# Altium schematic sheet IoBPMBlockDiagram V1.0.SchDoc("IoBPMBlockDiagram V1.0")
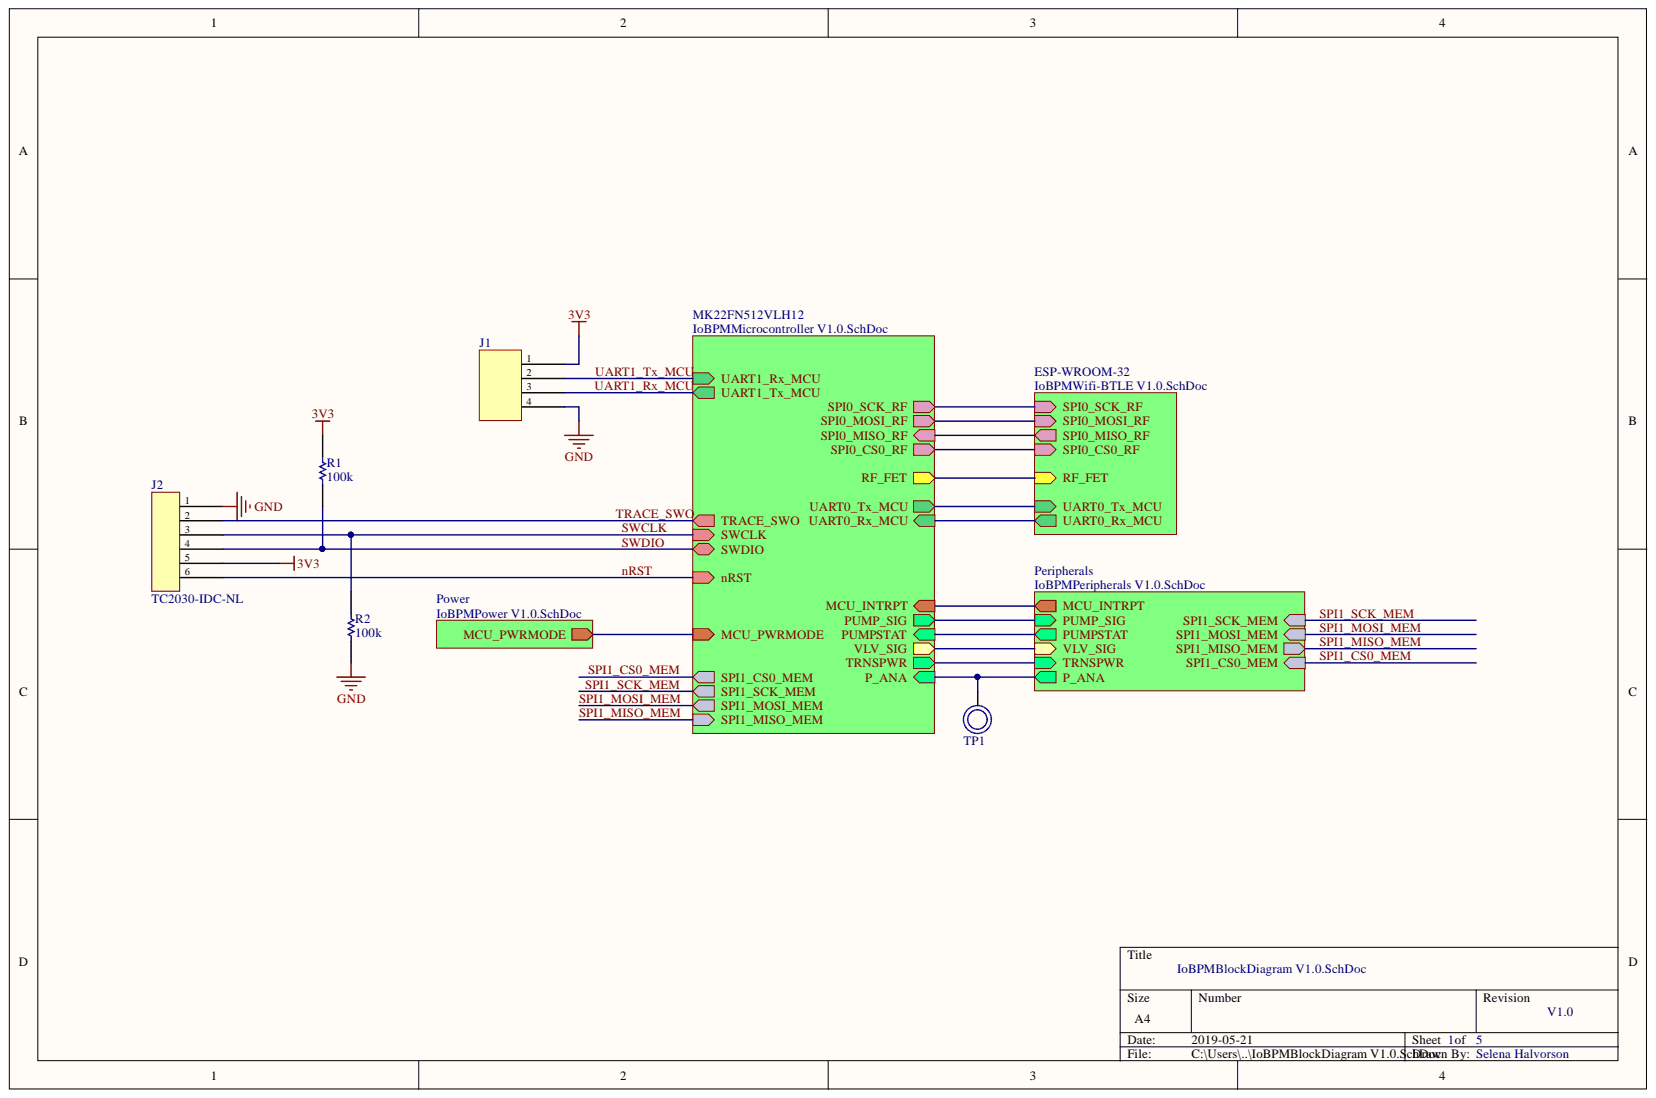
[diagram p0: IoBPMBlockDiagram V1.0.SchDoc]

## Parts
J1  PPPC041LFBN-RC  [CONN HEADER 4-pin 1 line Vertical]  (value not drawn)
J2  TC2030-IDC-NL  [TC2030-IDC-NL]
R1  100k  [Res 0402]
R2  100k  [Res 0402]
TP1  1.02mm Test Point  [1.02mm Test Point]  (value not drawn)

## Nets
3V3: J1.1 J2.5 R1.2
GND: J1.4 J2.1 R2.1
NRST: J2.6
SWCLK: J2.3 R2.2
SWDIO: J2.4 R1.1
TRACE_SWO: J2.2
UART1_RX_MCU: J1.3
UART1_TX_MCU: J1.2
NetTP1_1: TP1.1
MCU_INTRPT:
MCU_PWRMODE:
PUMP_SIG:
PUMPSTAT:
RF_FET:
SPI0_CS0_RF:
SPI0_MISO_RF:
SPI0_MOSI_RF:
SPI0_SCK_RF:
SPI1_CS0_MEM:
SPI1_MISO_MEM:
SPI1_MOSI_MEM:
SPI1_SCK_MEM:
TRNSPWR:
UART0_RX_MCU:
UART0_TX_MCU:
VLV_SIG:

## Library data of the parts
J1 = CONN HEADER 4POS 1ROW VERT: 4 Position Single Row Header Connector 0.100" (2.54mm) Through Hole Gold; Manufacturer: Sullins Connector Solutions; Internal P/N: IE00222; Component Kind: Standard; Library Name: mmHgLib.SchLib; Manufacturer P/N: PPPC041LFBN-RC
J2 = TC2030-IDC-NL: POGO CABLE ADAPTER 6 POS NO LEGS; Manufacturer: Tag-Connect LLC; Internal P/N: IQ00029; Component Kind: Standard; Library Name: mmHgLib.SchLib; Manufacturer P/N: TC2030-IDC-NL
R1 R2 = RES 100k, 0402: RES SMD 100K OHM 1% 1/10W 0402; Manufacturer: Panasonic Electronic Components; Manufacturer P/N: ERJ-2RKF1003X; Internal P/N: IE00063; Component Kind: Standard; Library Name: mmHgLib.SchLib
TP1 = Test Point: Designed as throughhole for d=1.02mm male connector; Component Kind: Standard; Library Name: mmHgLib.SchLib
